# Altium schematic sheet 0_Overview.SchDoc
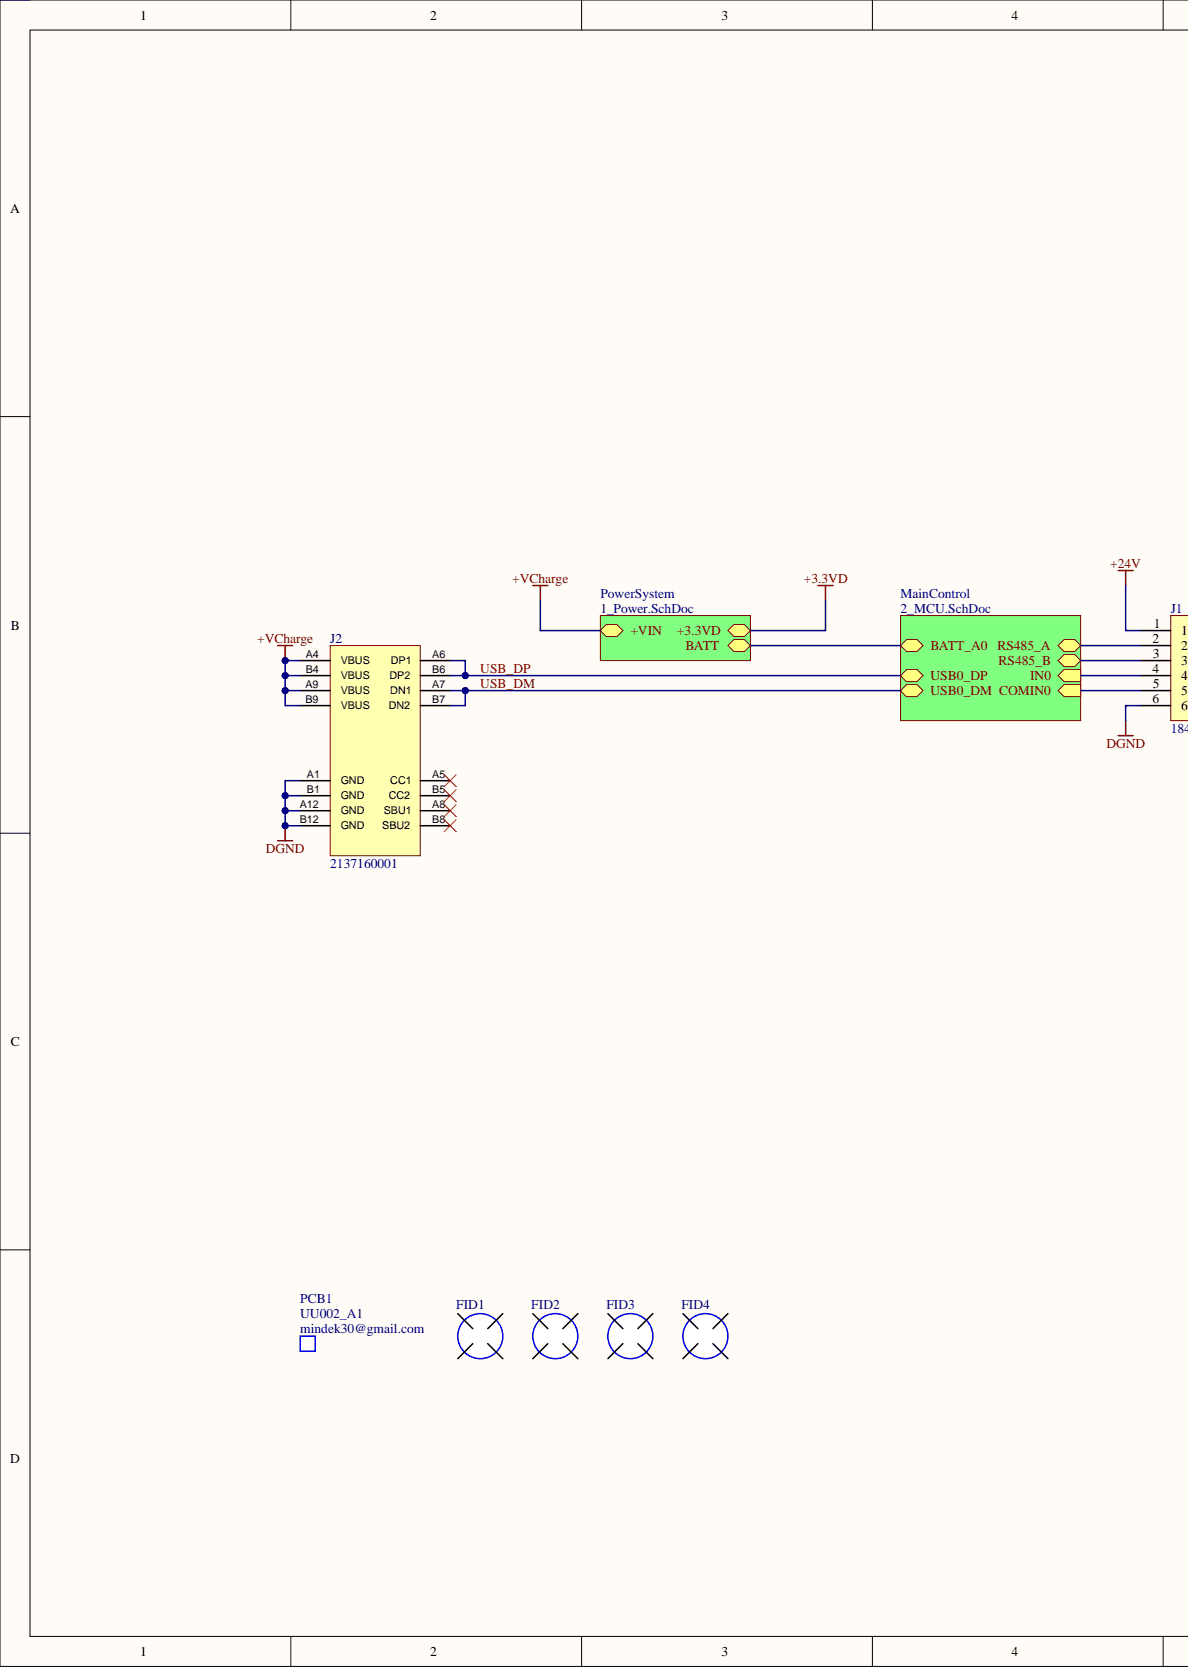
[diagram p0: 0_Overview.SchDoc, left]
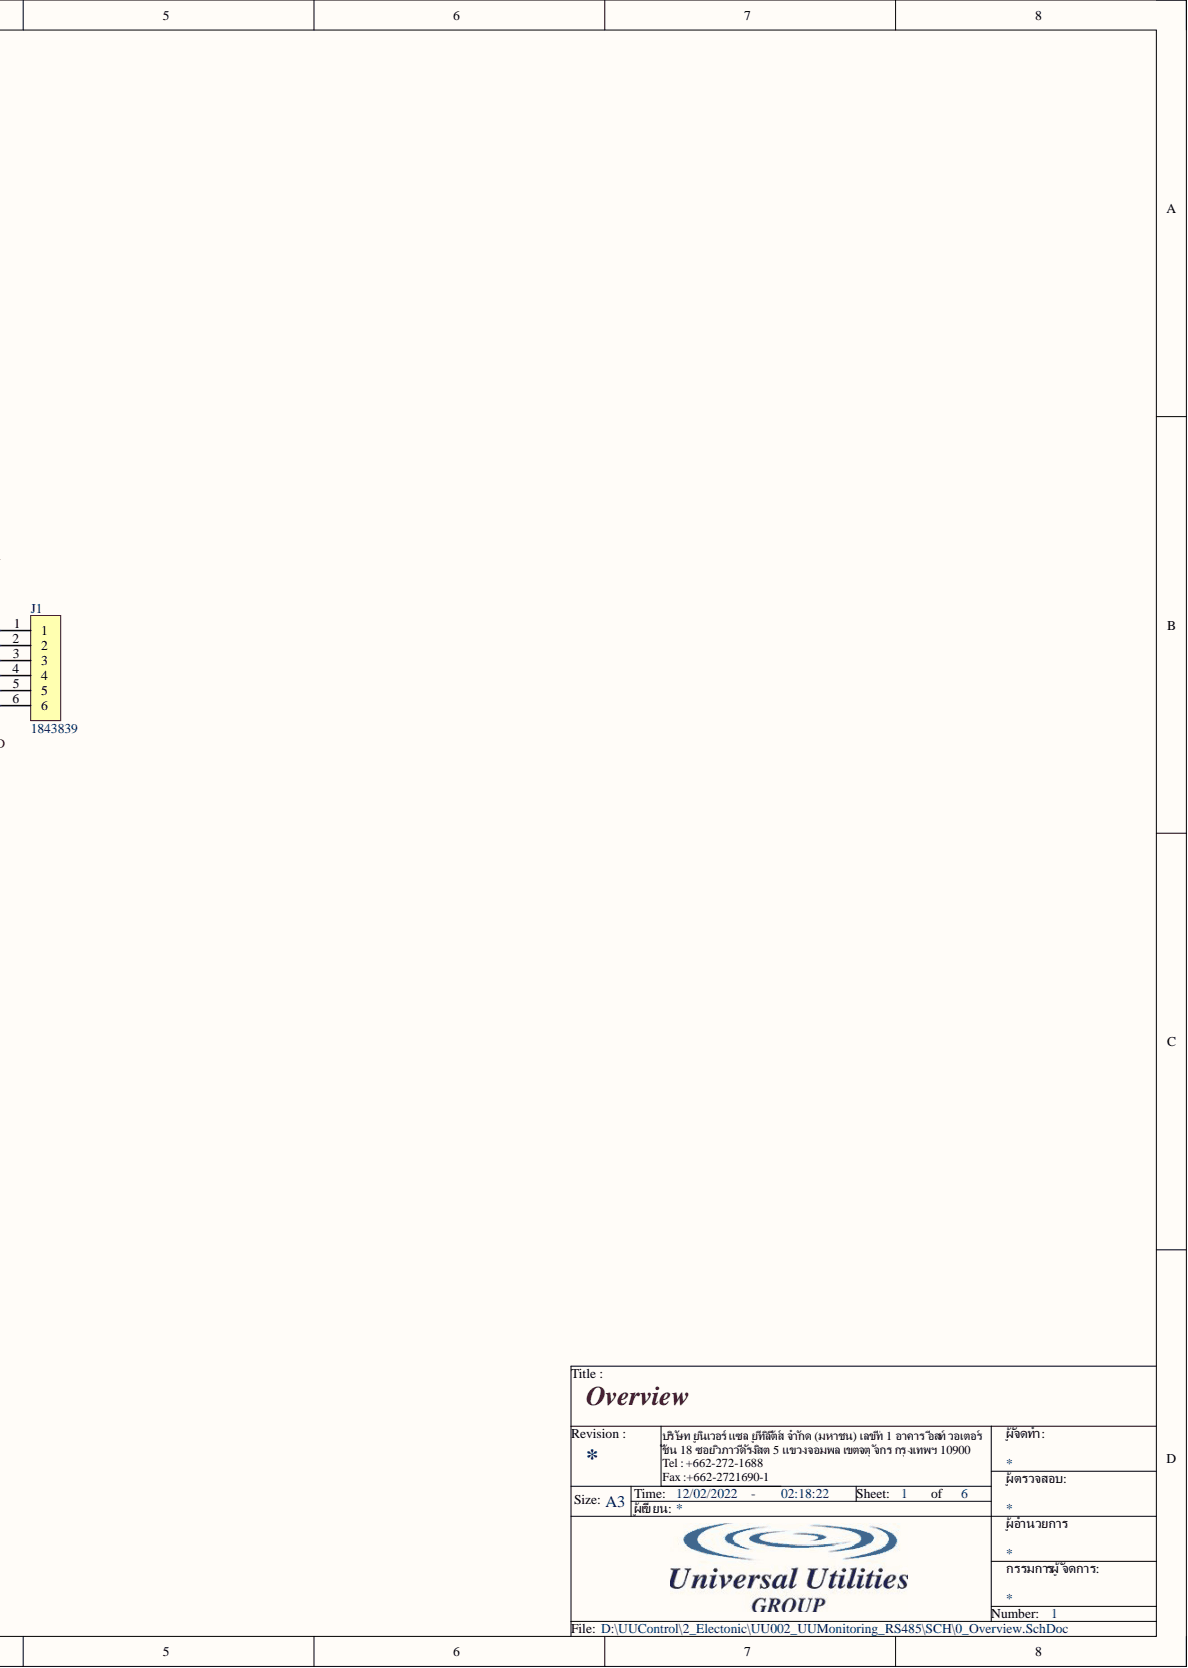
[diagram p1: 0_Overview.SchDoc, right]

## Parts
FID1  FIDUCIAL  [FID]  (value not drawn)  (no BOM)
FID2  FIDUCIAL  [FID]  (value not drawn)  (no BOM)
FID3  FIDUCIAL  [FID]  (value not drawn)  (no BOM)
FID4  FIDUCIAL  [FID]  (value not drawn)  (no BOM)
J1  1843839  [CON_6P_Terminal]
J2  2137160001  [2137160001_MOL_2137160001(Primary)]
PCB1  UU002_A1  Manufacturer: mindek30@gmail.com  (mechanical)

## Nets
+24V: J1.1
+VCharge: J2.A4(VBUS) J2.A9(VBUS) J2.B4(VBUS) J2.B9(VBUS)
DGND: J1.6 J2.A1(GND) J2.A12(GND) J2.B1(GND) J2.B12(GND)
USB_DM: J2.A7(DN1) J2.B7(DN2)
USB_DP: J2.A6(DP1) J2.B6(DP2)
NetJ1_2: J1.2
NetJ1_3: J1.3
NetJ1_4: J1.4
NetJ1_5: J1.5
NetJ2_A5: J2.A5(CC1)
NetJ2_A8: J2.A8(SBU1)
NetJ2_B5: J2.B5(CC2)
NetJ2_B8: J2.B8(SBU2)
+3.3VD:
BATT:

## Library data of the parts
FID1-FID4 = FID_FIDUCIAL: Manufacturer: mindek30@gmail.com; PartNumber: UU002_A1; Value: Non SE; Description: Fiducial; Library Name: UUSymbol.SchLib; PackageReference: Non SE; PartID: A1; Value2: Non SE; Value3: Non SE
J1 = CON_6P_Terminal: TERM BLOCK HDR 6POS 90DEG 3.5MM; Manufacturer: Phoenix Contact; Component Kind: Standard; PartID: 277-6291-ND; PartNumber: 1843839; Value: 1843839; Library Name: UUSymbol.SchLib
J2 = CON_USBC: CONN RCPT USB2.0 TYPE C 24POS RA; Manufacturer: Molex; Component Kind: Standard; PartID: 900-2137160001CT-ND; PartNumber: 2137160001; Value: 2137160001; Library Name: UUSymbol.SchLib
PCB1 = PCB_Bare: BARE PCB; Library Name: UUSymbol.SchLib; PackageReference: Non SE; PartID: A1; PartNumber: UU002_A1; Value: UU002_A1; Value3: UU002_A1
